# Altium schematic sheet 03_USB_TO_UART.SchDoc(03_USB_TO_UART)
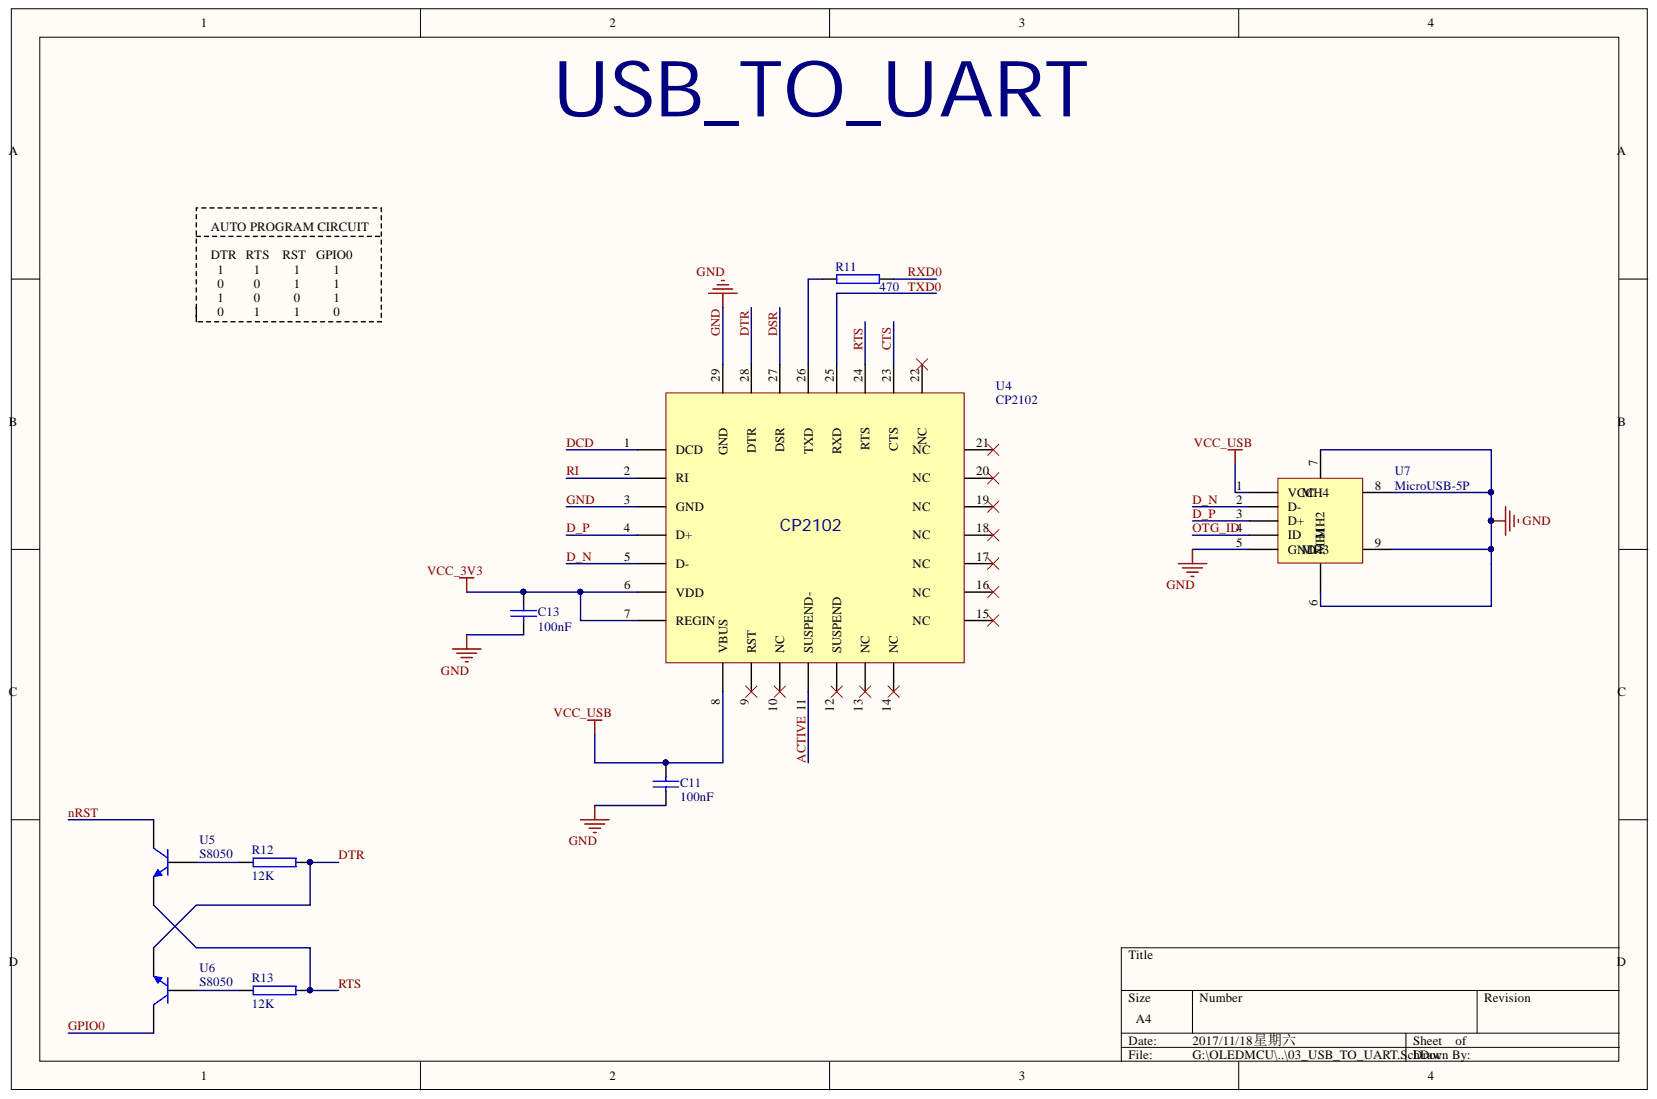
[diagram p0: 03_USB_TO_UART.SchDoc]

## Parts
C11  100nF  [C0603]
C13  100nF  [C0603]
R11  470  [R0603]
R12  12K  [R0603]
R13  12K  [R0603]
U4  CP2102  [cp2102]
U5  S8050  [s8050]
U6  S8050  [s8050]
U7  MicroUSB-5P  [MICROUSB_5S]

## Nets
VCC_3V3: C13.1 U4.6(VDD) U4.7(REGIN)
VCC_USB: C11.1 U4.8(VBUS) U7.1(VCC)
ACTIVE: U4.11(SUSPEND-)
CTS: U4.23
D_N: U4.5(D-) U7.2(D-)
D_P: U4.4(D+) U7.3(D+)
DCD: U4.1(DCD)
DSR: U4.27
DTR: R12.2 U4.28 U6.2
GND: C11.2 C13.2 U4.3(GND) U4.29 U7.5(GND) U7.6(MH1) U7.7 U7.8 U7.9
GPIO0: U6.3
NRST: U5.3
OTG_ID: U7.4(ID)
RI: U4.2(RI)
RTS: R13.2 U4.24 U5.2
RXD0: R11.2
TXD0: U4.25
NetR11_1: R11.1 U4.26
NetR12_1: R12.1 U5.1
NetR13_1: R13.1 U6.1

Not connected: U4 9(RST) 10(NC) 12(SUSPEND) 13(NC) 14(NC) 15 16 17 18 19 20 21 22

## Library data of the parts
C11 C13 = C0603: 贴片电容; Component Kind: Standard; Library Name: Schlib_OLEDMCU.SchLib
R11-R13 = R0603: 贴片电阻; Component Kind: Standard; Library Name: Schlib_OLEDMCU.SchLib
U4 = CP2102: USB转串口芯片; Component Kind: Standard; Library Name: Schlib_OLEDMCU.SchLib
U5 U6 = S8050: 三极管; Component Kind: Standard; Library Name: Schlib_OLEDMCU.SchLib
U7 = MicroUSB_5S: Micro USB插脚; Component Kind: Standard; Library Name: Schlib_OLEDMCU.SchLib
